# Altium schematic sheet Amp.SchDoc
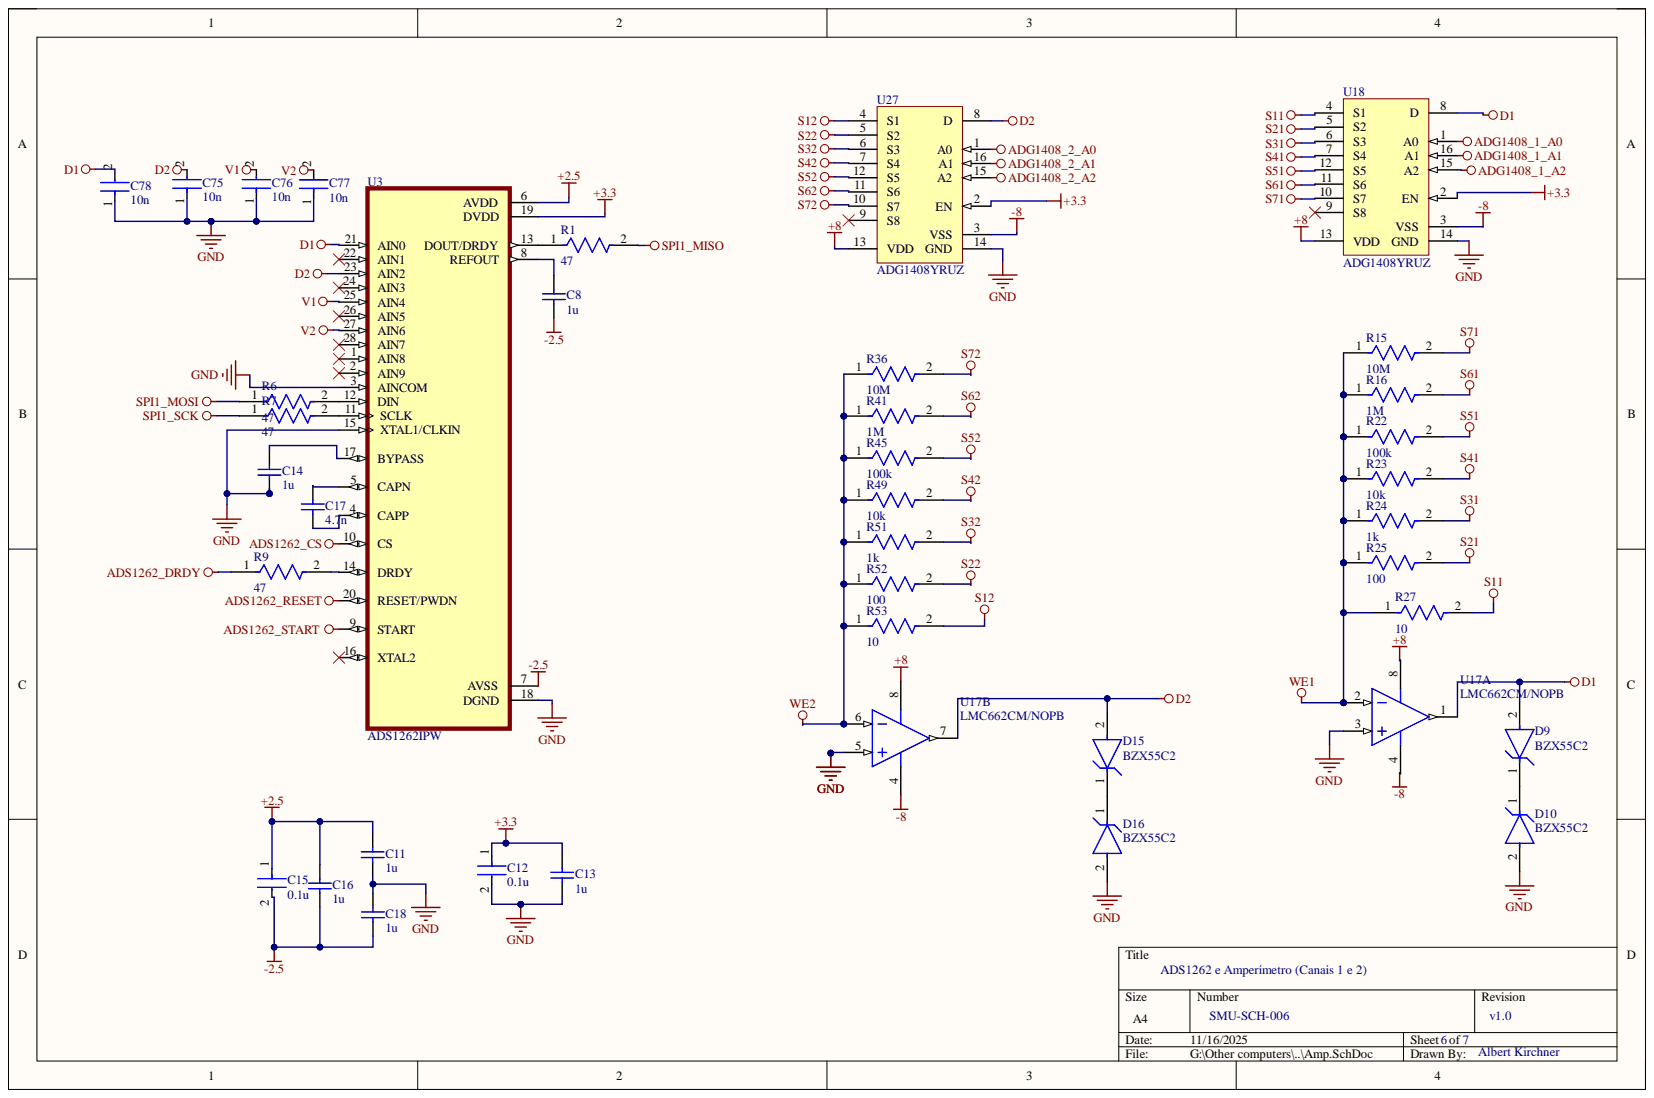
[diagram p0: Amp.SchDoc]

## Parts
C8  1u  [CAPC2013X140X50LL20T25]
C11  1u  [CAPC2013X140X50LL20T25]
C12  0.1u  [FP-CC0805-0_85-MFG]
C13  1u  [CAPC2013X140X50LL20T25]
C14  1u  [CAPC2013X140X50LL20T25]
C15  0.1u  [FP-CC0805-0_85-MFG]
C16  1u  [CAPC2013X140X50LL20T25]
C17  4.7n  [CAPC2013X70X45ML10T25]
C18  1u  [CAPC2013X140X50LL20T25]
C75  10n  [FP-0805-L_2_01_0_2-W_1_25-IPC_B]
C76  10n  [FP-0805-L_2_01_0_2-W_1_25-IPC_B]
C77  10n  [FP-0805-L_2_01_0_2-W_1_25-IPC_B]
C78  10n  [FP-0805-L_2_01_0_2-W_1_25-IPC_B]
D9  BZX55C2  [FP-SB-V-3906_04-031_4-MFG]
D10  BZX55C2  [FP-SB-V-3906_04-031_4-MFG]
D15  BZX55C2  [FP-SB-V-3906_04-031_4-MFG]
D16  BZX55C2  [FP-SB-V-3906_04-031_4-MFG]
R1  47  [FP-CR1206-MFG]
R6  47  [FP-CR1206-MFG]
R7  47  [FP-CR1206-MFG]
R9  47  [FP-CR1206-MFG]
R15  10M  [FP-CR1206-MFG]
R16  1M  [FP-CR1206-MFG]
R22  100k  [FP-CR1206-MFG]
R23  10k  [FP-CR1206-MFG]
R24  1k  [FP-CR1206-MFG]
R25  100  [FP-CR1206-MFG]
R27  10  [FP-CRCW1210-e3-IPC_B]
R36  10M  [FP-CR1206-MFG]
R41  1M  [FP-CR1206-MFG]
R45  100k  [FP-CR1206-MFG]
R49  10k  [FP-CR1206-MFG]
R51  1k  [FP-CR1206-MFG]
R52  100  [FP-CR1206-MFG]
R53  10  [FP-CRCW1210-e3-IPC_B]
U3  ADS1262IPW  [SOP65P640X120-28N]
U17  LMC662CM/NOPB  [D0008A_L]  (parts A,B)
U18  ADG1408YRUZ  [ADI-RU-16_N]
U27  ADG1408YRUZ  [ADI-RU-16_N]

## Nets
+2.5: C11.2 C15.1 C16.2 U3.6(AVDD)
+3.3: C12.1 C13.2 U3.19(DVDD) U18.2(EN) U27.2(EN)
+8: U17.8 U18.13(VDD) U27.13(VDD)
-2.5: C8.1 C15.2 C16.1 C18.1 U3.7(AVSS)
-8: U17.4 U18.3(VSS) U27.3(VSS)
ADG1408_1_A0: U18.1(A0)
ADG1408_1_A1: U18.16(A1)
ADG1408_1_A2: U18.15(A2)
ADG1408_2_A0: U27.1(A0)
ADG1408_2_A1: U27.16(A1)
ADG1408_2_A2: U27.15(A2)
ADS1262_CS: U3.10(CS)
ADS1262_DRDY: R9.1
ADS1262_RESET: U3.20(RESET/PWDN)
ADS1262_START: U3.9(START)
D1: C78.2 D9.2 U3.21(AIN0) U17.1 U18.8(D)
D2: C75.2 D15.2 U3.23(AIN2) U17.7 U27.8(D)
GND: C11.1 C12.2 C13.1 C14.1 C18.2 C75.1 C76.1 C77.1 C78.1 D10.2 D16.2 U3.3(AINCOM) U3.15(XTAL1/CLKIN) U3.18(DGND) U17.3 U17.5 U18.14(GND) U27.14(GND)
S11: R27.2 U18.4(S1)
S12: R53.2 U27.4(S1)
S21: R25.2 U18.5(S2)
S22: R52.2 U27.5(S2)
S31: R24.2 U18.6(S3)
S32: R51.2 U27.6(S3)
S41: R23.2 U18.7(S4)
S42: R49.2 U27.7(S4)
S51: R22.2 U18.12(S5)
S52: R45.2 U27.12(S5)
S61: R16.2 U18.11(S6)
S62: R41.2 U27.11(S6)
S71: R15.2 U18.10(S7)
S72: R36.2 U27.10(S7)
SPI1_MISO: R1.2
SPI1_MOSI: R6.1
SPI1_SCK: R7.1
V1: C76.2 U3.25(AIN4)
V2: C77.2 U3.27(AIN6)
WE1: R15.1 R16.1 R22.1 R23.1 R24.1 R25.1 R27.1 U17.2
WE2: R36.1 R41.1 R45.1 R49.1 R51.1 R52.1 R53.1 U17.6
NetC8_2: C8.2 U3.8(REFOUT)
NetC14_2: C14.2 U3.17(BYPASS)
NetC17_1: C17.1 U3.4(CAPP)
NetC17_2: C17.2 U3.5(CAPN)
NetD9_1: D9.1 D10.1
NetD15_1: D15.1 D16.1
NetR1_1: R1.1 U3.13(DOUT/DRDY)
NetR6_2: R6.2 U3.12(DIN)
NetR7_2: R7.2 U3.11(SCLK)
NetR9_2: R9.2 U3.14(DRDY)

Not connected: U3 1(AIN8) 2(AIN9) 16(XTAL2) 22(AIN1) 24(AIN3) 26(AIN5) 28(AIN7); U18 9(S8); U27 9(S8)

## Library data of the parts
C8 C11 C13 C14 C16 C18 = CMP-2007-03234-1: Manufacturer: Kemet; Part Number: C0805C105K3RACTU; Component Kind: Standard; Library Name: Altium Content Vault
C12 C15 = CMP-2100-03606-3: CAP CER 0.1UF 50V X7R 0805; Manufacturer: Yageo; Manufacturer Part Number: CC0805KRX7R9BB104; Capacitance: 100 nF; Material: Ceramic; Tolerance: 0.1; Value: 100 nF; Automotive: No; Category: Cap; Component Kind: Standard; ComponentLink2Description: Datasheet; ComponentLink2URL: http://www.yageo.com/documents/recent/UPY-GPHC_Y5V_6.3V-to-50V_8.pdf; Device Class L1: Passive Components; Device Class L2: Capacitors; Device Class L3: Ceramic Capacitors; Digikey Description: CAP CER 0.1UF 50V X7R 0805; Footprint Url: http://www.yageo.com/exep/pages/download/literatures/UPY-C_GEN_24.pdf; Height: 0.95mm; Ipc Land Pattern Name: CAPC200125X85; Lead Free: Yes; Library Name: Altium Content Vault; Mouser Description: Multilayer Ceramic Capacitors MLCC - SMD/SMT 100nF 50V X7R 10%; Octopart: https://octopart.com/cc0805krx7r9bb104-yageo-192993; Package: CC0805-0.85; RoHS: TRUE; Temperature: -30°C to +85°C; Temperature Characteristic: X7R; Temperature Range High: +85°C; Temperature Range Low: -30°C; Voltage Rating: 50 V
C17 = CMP-2007-00997-1: Manufacturer: Murata; Part Number: GRM216R71H472KA01D; Component Kind: Standard; Library Name: Altium Content Vault
C75-C78 = CMP-2007-04119-2: General Purpose Ceramic Capacitor, 0805, 100pF, 1%, C0G, 30ppm/°C, 100V; Capacitance: 100pF; Case Code (Imperial): 0805; Case Code (Metric): 2012; Case/Package: 0805; Component Kind: Standard; Construction: Flat; Depth: 1.25mm; Dielectric: C0G; Dielectric Material: Ceramic; Height: 0.71mm; Lead Free: Lead Free; Length: 2.01mm; Library Name: Altium Content Vault; Max Operating Temperature: 125°C; Min Operating Temperature: -55°C; Mount: Surface Mount; Number of Pins: 2; Package Quantity: 1; Packaging: Tape and Reel; Radiation Hardening: No; REACH SVHC: No SVHC; RoHS Compliant: Yes; Series: -; Termination: SMD/SMT; Thickness: 0.037inch; Tolerance: 1%; Voltage: 100V; Voltage Rating: 100V; Voltage Rating (DC): 100V; Width: 1.25mm
D9 D10 D15 D16 = CMP-02541-000018-1: DIODE ZENER 10V 500MW DO35; Breakdown Voltage: 10.6V; Element Configuration: Single; Impedance: 15R; Max Reverse Leakage Current: 100nA; Test Current: 5mA; Tolerance: 5%; Voltage Tolerance: 6%; Case/Package: DO-35; Component Kind: Standard; Lead Free: Lead Free; Library Name: Altium Content Vault; Max Operating Temperature: 175°C; Max Power Dissipation: 500mW; Min Operating Temperature: -65°C; Mount: Through Hole; Number of Pins: 2; Package Quantity: 30000; Packaging: Tape and Reel; Power Dissipation: 500mW; Radiation Hardening: No; RoHS Compliant: Yes; Series: Automotive, AEC-Q101; Zener Voltage: 10V
R1 R6 R7 R9 R15 R16 R22-R25 R36 R41 R45 R49 R51 R52 = CMP-07231-003158-1: Thick Film Chip Resistors 1206 330Ω 0.25W 1% 100ppm/°C; Max Power Dissipation: 250mW; Resistance: 330R; Voltage Rating: 200V; Case Code (Imperial): 1206; Case Code (Metric): 3216; Case/Package: 1206; Component Kind: Standard; Composition: Thick Film; Contact Plating: Tin; Depth: 1.6mm; Height: 0.6mm; Length: 3.2mm; Library Name: Altium Content Vault; Max Operating Temperature: 155°C; Military Standard: Not; Min Operating Temperature: -55°C; Mount: Surface Mount; Number of Pins: 2; Number of Terminations: 2; Package Quantity: 5000; Packaging: Tape and Reel; Power Rating: 250mW; Radiation Hardening: No; REACH SVHC: No SVHC; Temperature Coefficient: 100ppm/°C; Termination: SMD/SMT; Thickness: 610um; Tolerance: 1%; Voltage Rating (DC): 200V; Width: 1.6mm
R27 R53 = CMP-2005-01987-2: RES SMD 205K OHM 1% 1/2W 1992; Max Power Dissipation: 500mW; Resistance: 0mR; Temperature Coefficient: 0ppm/°C; Voltage Rating: 200V; Case Code (Imperial): 1210; Case Code (Metric): 3225; Case/Package: 1210; Component Kind: Standard; Composition: Thick Film; Contact Plating: Tin; Depth: 2.5mm; Features: Automotive AEC-Q200; Height: 0.55mm; Length: 3.2mm; Library Name: Altium Content Vault; Max Operating Temperature: 155°C; Military Standard: Not; Min Operating Temperature: -55°C; Mount: Surface Mount; Number of Terminations: 2; Package Quantity: 1; Packaging: Tape and Reel; Power Rating: 500mW; Radiation Hardening: No; REACH SVHC: No SVHC; Termination: SMD/SMT; Weight: 0.000564oz; Width: 0.098inch
U17 = CMP-0055-00892-3: CMOS Dual Operational Amplifier, 8-pin Narrow SOIC, Pb-Free; Manufacturer: Texas Instruments; CompType: Amplifiers; PackageTopMarking: NSZXTT*LMC66*2CM; PowerWise: N; Code_IPC: SOIC127P600-8; Code_JEDEC: MS-012-AA; Component Kind: Standard; ComponentLink1Description: Datasheet URL; ComponentLink1URL: http://www.ti.com/general/docs/lit/getliterature.tsp?genericPartNumber=LMC662&fileType=pdf; Library Name: Altium Content Vault; Package Reference: D0008A; PackageDescription: SOIC Narrow, 4.9 x 3.9 x 1.45mm, 8 Lead, 1.27mm Pitch; PinCount: 8; RoHS: Y
U18 U27 = CMP-0793-00127-1: 4 Ohm Ron, 8-Channel +/-15 V / +12 V / +/-5 V iCMOS Multiplexer, 16-pin SOP (RU-16), Tube; Manufacturer: Analog Devices; Bandwidth (Hz): 60M, 36M, 40M; Max Analog Signal Range: Vss to Vdd; Ron (ohms): 4; t transition (s): 140n; Code_JEDEC: MO-153AB; Component Kind: Standard; ComponentLink1Description: Manufacturer URL; ComponentLink1URL: http://www.analog.com/en/index.html; ComponentLink2Description: Datasheet; ComponentLink2URL: http://www.analog.com/static/imported-files/data_sheets/ADG1408_1409.pdf; ComponentLink3Description: Package Specification; ComponentLink3URL: http:/www.analog.com/static/imported-files/packages/36244347913743854010197452789RU16.pdf; DatasheetVersion: Rev. B; Library Name: Altium Content Vault; Mounting Technology: Surface Mount; PackageDescription: 16-Pin Thin Shrink Small Outline Package, Body 5 x 4.4 mm, Pitch 0.65 mm; PackageReference: RU-16; PackageVersion: Nov-02; Packing: Tube; PartNumber: ADG1408YRUZ
U3 = ADS1262IPW: Availability: Unavailable; Component Kind: Standard; Library Name: ADS1262IPW.IntLib; MP: ADS1262IPW; Package: None; Price: None
